# Altium schematic sheet RS232-TS.SchDoc(RS232-TS)
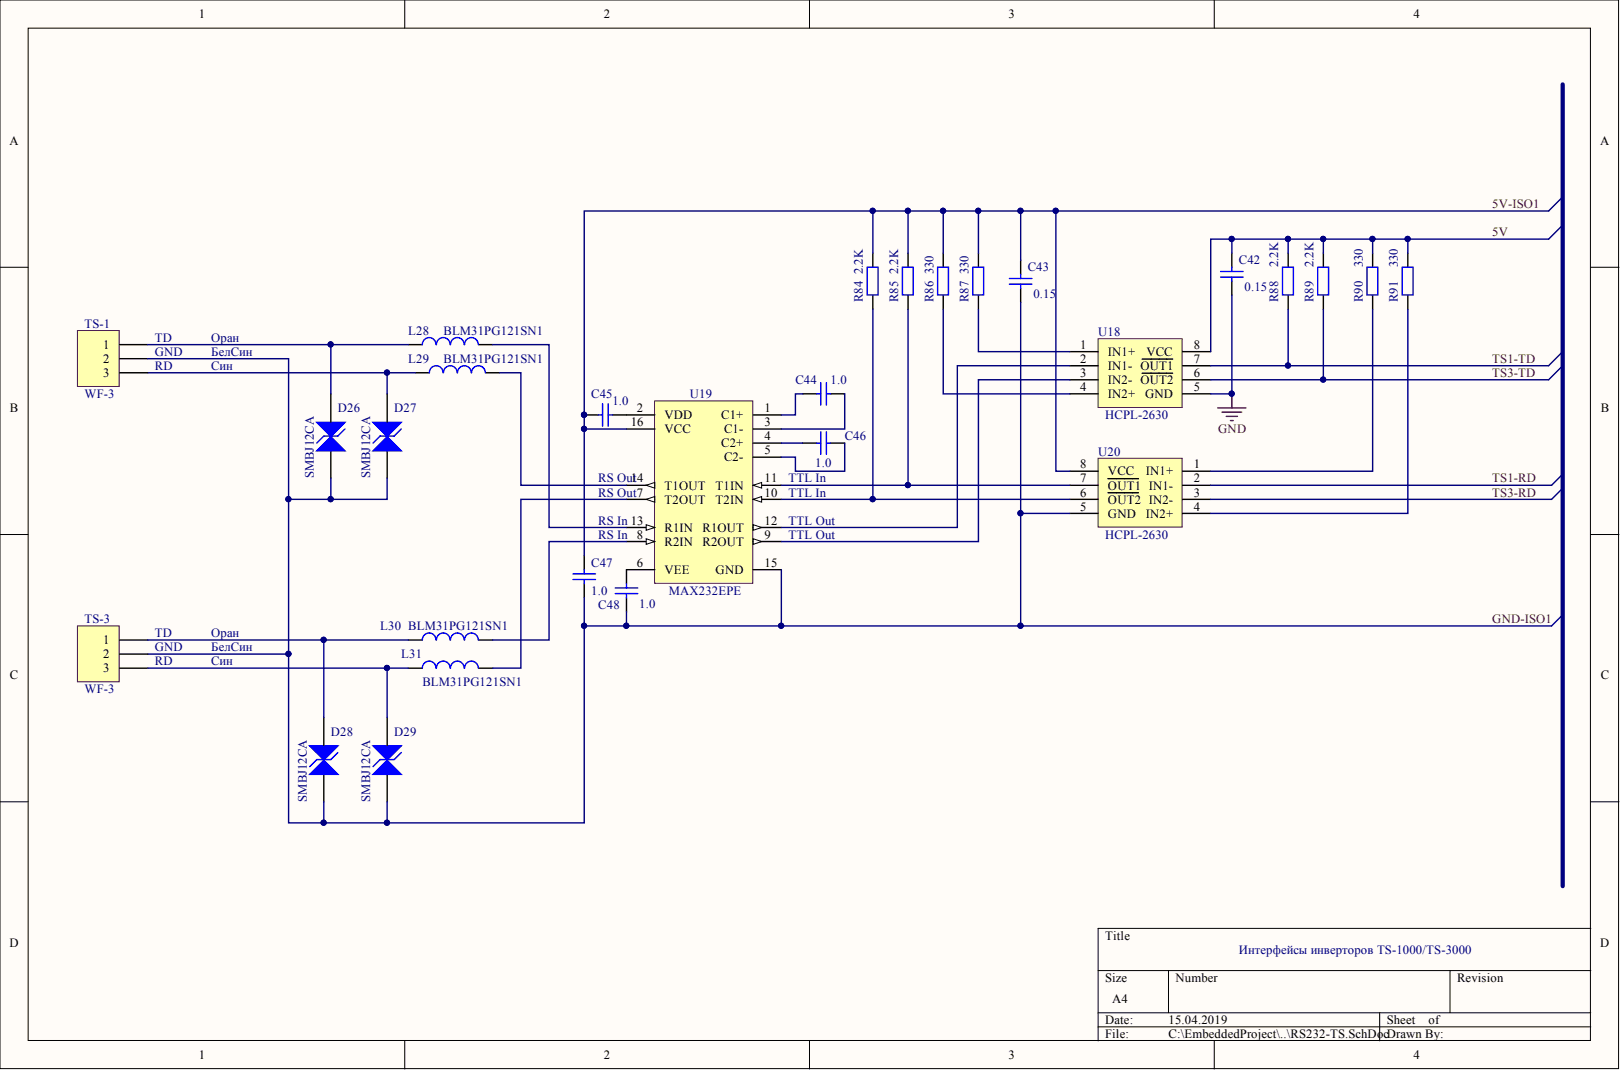
[diagram p0: RS232-TS.SchDoc]

## Parts
C42  value 0.15  [C1206]  (hidden comment: Cap)
C43  value 0.15  [C1206]  (hidden comment: Cap)
C44  value 1.0  [C1206]  (hidden comment: Cap)
C45  value 1.0  [C1206]  (hidden comment: Cap)
C46  value 1.0  [C1206]  (hidden comment: Cap)
C47  value 1.0  [C1206]  (hidden comment: Cap)
C48  value 1.0  [C1206]  (hidden comment: Cap)
D26  SMBJ12CA  [DO-214AA]
D27  SMBJ12CA  [DO-214AA]
D28  SMBJ12CA  [DO-214AA]
D29  SMBJ12CA  [DO-214AA]
L28  value BLM31PG121SN1  [L1206]  (hidden comment: Ferrite Chip Beads)
L29  value BLM31PG121SN1  [L1206]  (hidden comment: Ferrite Chip Beads)
L30  value BLM31PG121SN1  [L1206]  (hidden comment: Ferrite Chip Beads)
L31  value BLM31PG121SN1  [L1206]  (hidden comment: Ferrite Chip Beads)
R84  value 2.2K  [1206]  (hidden comment: Res2)
R85  value 2.2K  [1206]  (hidden comment: Res2)
R86  value 330  [1206]  (hidden comment: Res2)
R87  value 330  [1206]  (hidden comment: Res2)
R88  value 2.2K  [1206]  (hidden comment: Res2)
R89  value 2.2K  [1206]  (hidden comment: Res2)
R90  value 330  [1206]  (hidden comment: Res2)
R91  value 330  [1206]  (hidden comment: Res2)
TS-1  value WF-3  [WF-3]  (hidden comment: Header, 3-Pin)
TS-3  value WF-3  [WF-3]  (hidden comment: Header, 3-Pin)
U18  HCPL-2630  [DIP-8]
U19  value MAX232EPE  [DIP16]  (hidden comment: +5V Powered RS-232 Driver/Receiver)
U20  HCPL-2630  [DIP-8]

## Nets
GND: C42.1 U18.5(GND)
5V: C42.2 R88.1 R89.1 R90.1 R91.1 U18.8(VCC)
5V-ISO1: C43.2 C45.1 C47.1 R84.1 R85.1 R86.1 R87.1 U19.16(VCC) U20.8(VCC)
GND-ISO1: C43.1 C47.2 C48.2 D26.1 D27.1 D28.1 D29.1 TS-1.2 TS-3.2 U19.15(GND) U20.5(GND)
TS1-RD: U20.2(IN1-)
TS1-TD: R88.2 U18.7(OUT1)
TS3-RD: U20.3(IN2-)
TS3-TD: R89.2 U18.6(OUT2)
NetC44_1: C44.1 U19.1(C1+)
NetC44_2: C44.2 U19.3(C1-)
NetC45_2: C45.2 U19.2(VDD)
NetC46_1: C46.1 U19.4(C2+)
NetC46_2: C46.2 U19.5(C2-)
NetC48_1: C48.1 U19.6(VEE)
NetD26_2: D26.2 L28.1 TS-1.1
NetD27_2: D27.2 L29.1 TS-1.3
NetD28_2: D28.2 L30.1 TS-3.1
NetD29_2: D29.2 L31.1 TS-3.3
NetL28_2: L28.2 U19.13(R1IN)
NetL29_2: L29.2 U19.14(T1OUT)
NetL30_2: L30.2 U19.8(R2IN)
NetL31_2: L31.2 U19.7(T2OUT)
NetR84_2: R84.2 U19.10(T2IN) U20.6(OUT2)
NetR85_2: R85.2 U19.11(T1IN) U20.7(OUT1)
NetR86_2: R86.2 U18.4(IN2+)
NetR87_2: R87.2 U18.1(IN1+)
NetR90_2: R90.2 U20.1(IN1+)
NetR91_2: R91.2 U20.4(IN2+)
NetU18_2: U18.2(IN1-) U19.12(R1OUT)
NetU18_3: U18.3(IN2-) U19.9(R2OUT)
N00716:

## Library data of the parts
C42-C48 = Cap: Capacitor; Component Kind: Standard; LatestRevisionDate: 17-Jul-2002; LatestRevisionNote: Re-released for DXP Platform.; Library Name: Miscellaneous Devices.IntLib; PackageReference: RAD-0.3; Published: 8-Jun-2000; Signal Integrity: Cap; Simulation: CAP; Publisher: Altium Limited
D26-D29 = SMBJ12CA: Voltage suppressor; Component Kind: Standard; Library Name: Schlib1.SchLib; Value: SMBJ12CA
L28-L31 = Inductor: Code_IEC: 1005; Code_JEITA: 0402; Component Kind: Standard; Description: Ferrite Chip Beads; LatestRevisionDate: 29-May-2009; LatestRevisionNote: IPC-7351 Footprint Added.; Library Name: Miscellaneous Devices.IntLib; PackageDescription: Chip Inductor; PackageReference: 0402-A; Published: 8-Jun-2000; Signal Integrity: Inductor; Simulation: INDUCTOR; Publisher: Altium Limited
R84-R91 = Res2: Resistor; Component Kind: Standard; LatestRevisionDate: 17-Jul-2002; LatestRevisionNote: Re-released for DXP Platform.; Library Name: Miscellaneous Devices.IntLib; PackageReference: AXIAL-0.4; Published: 8-Jun-2000; Signal Integrity: Res; Simulation: RESISTOR; Publisher: Altium Limited
TS-1 TS-3 = Header 3: Component Kind: Standard; Description: Header, 3-Pin; LatestRevisionDate: 17-Jul-2002; LatestRevisionNote: Re-released for DXP Platform.; Library Name: Miscellaneous Connectors.IntLib; Signal Integrity: Connector; Publisher: Altium Limited
U18 U20 = HCPL-2630: High speed-10 mbit/s logic gate optocouplers dual-channel; Component Kind: Standard; Library Name: Schlib1.SchLib; Value: HCPL-2630
U19 = MAX232EPE: Code_JEDEC: MS-001-BB; Component Kind: Standard; ComponentLink1Description: Manufacturer Link; ComponentLink1URL: http://www.maxim-ic.com/; ComponentLink2Description: Datasheet; ComponentLink2URL: http://pdfserv.maxim-ic.com/en/ds/MAX220-MAX249.pdf; DatasheetVersion: Apr-1992; Description: +5V Powered RS-232 Driver/Receiver; LatestRevisionDate: 29-May-2009; LatestRevisionNote: Component Body Added.; Library Name: Maxim Communication Transceiver.IntLib; PackageDescription: DIP, 16-Pin, Row Spacing 7.62 mm, Pitch 2.54 mm; PackageReference: PE16A; PackageVersion: 1997; PCB3D: PE16; Published: 16-Jan-2001; Publisher: Altium Limited
